# Altium schematic sheet COM.SchDoc(COM)
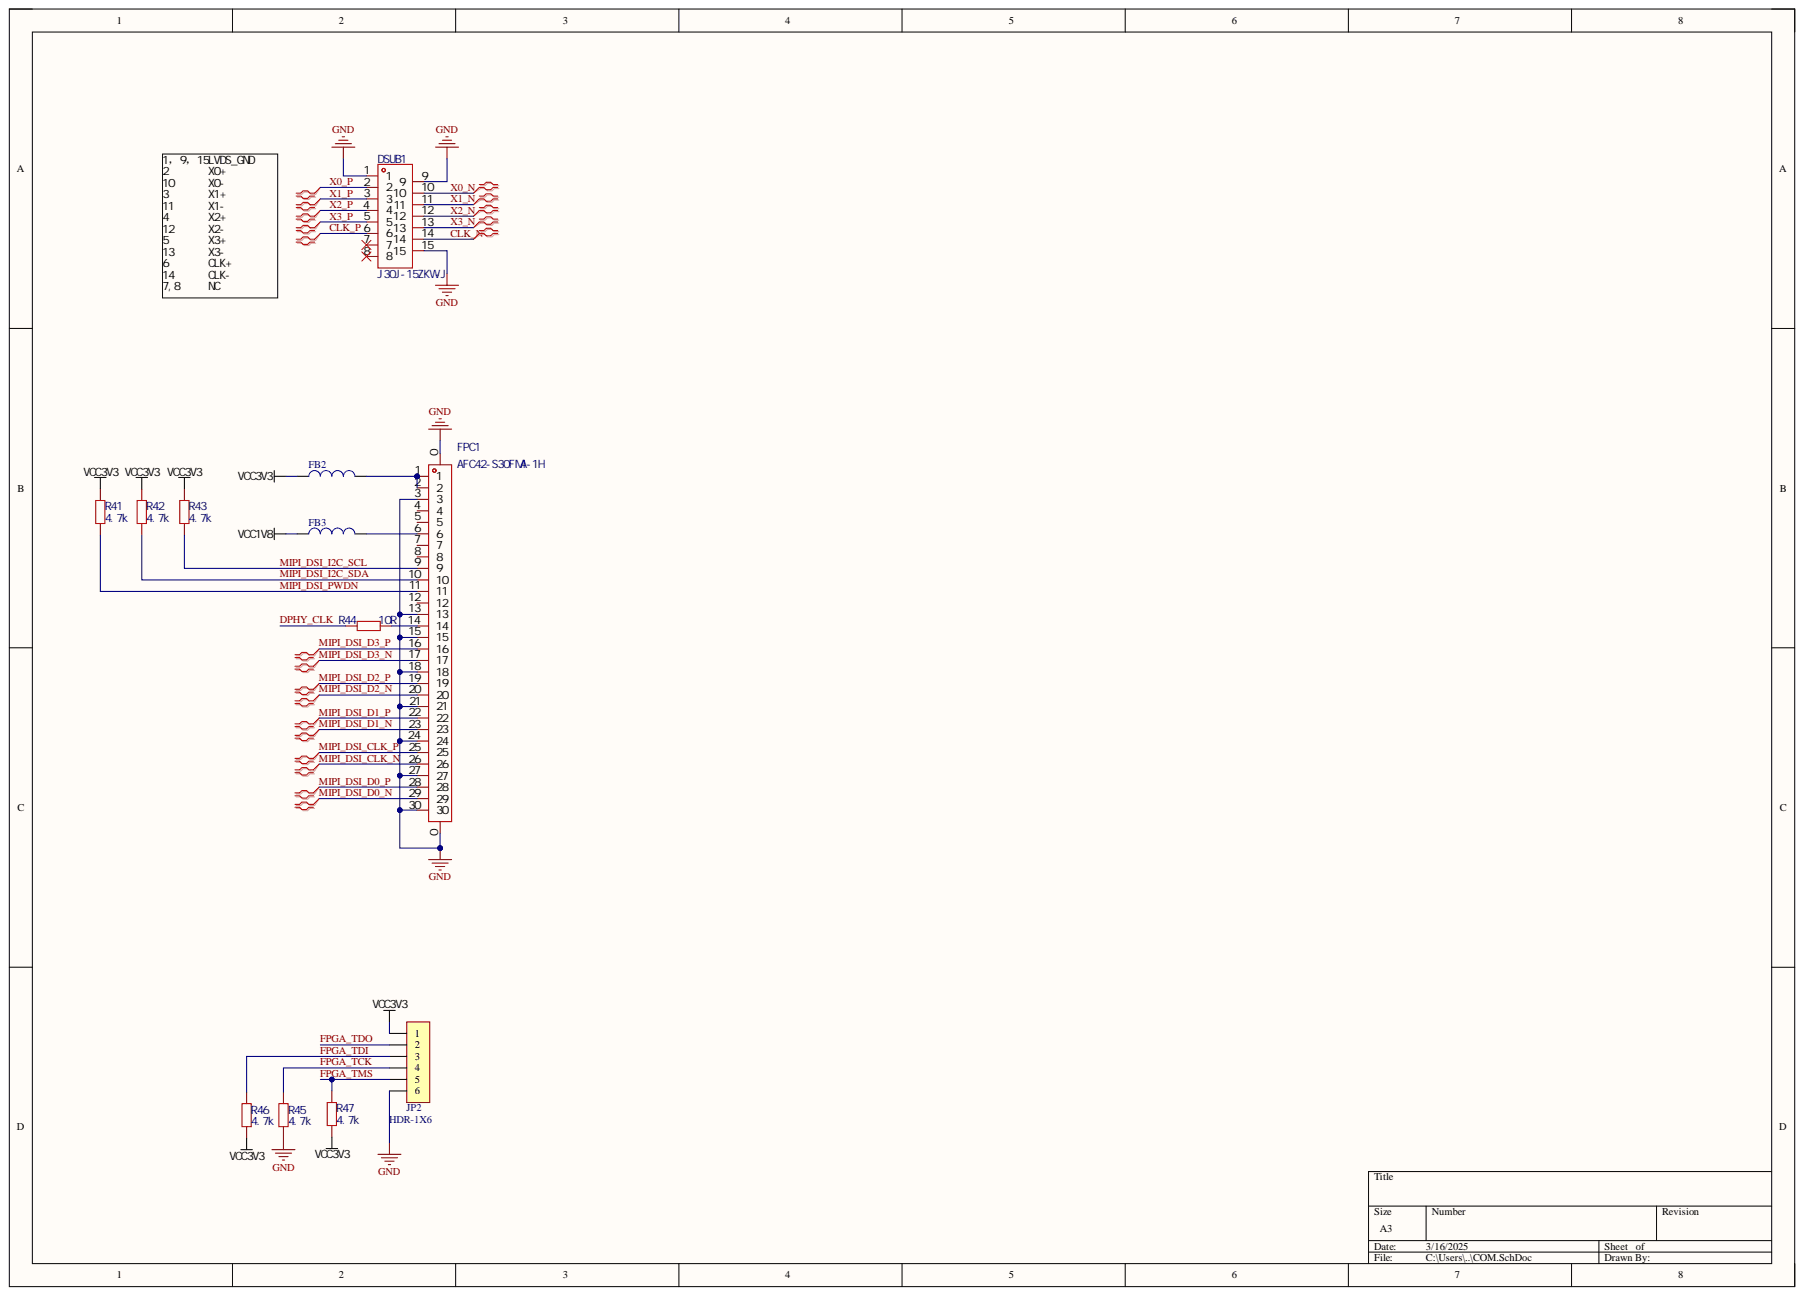
[diagram p0: COM.SchDoc]

## Parts
DSUB1  J30J-15ZKW-J  [DSUB-TH_J30J-15ZKW-J]
FB2  Inductor  [1608[0603]]  (value not drawn)
FB3  Inductor  [1608[0603]]  (value not drawn)
FPC1  AFC42-S30FMA-1H  [CONN-SMD_30P-P0.50_L3.1-W17.0]
JP2  HDR-1X6  [HDR1X6]
R41  4.7k  [0402]
R42  4.7k  [0402]
R43  4.7k  [0402]
R44  10R  [0402]
R45  4.7k  [0402]
R46  4.7k  [0402]
R47  4.7k  [0402]

## Nets
GND: DSUB1.1 DSUB1.9 DSUB1.15 FPC1.0 FPC1.3 FPC1.13 FPC1.15 FPC1.18 FPC1.21 FPC1.24 FPC1.27 FPC1.30 JP2.6 R45.2
VCC1V8: FB3.1
VCC3V3: FB2.1 JP2.1 R41.2 R42.2 R43.2 R46.2 R47.2
CLK_N: DSUB1.14
CLK_P: DSUB1.6
DPHY_CLK: R44.1
FPGA_TCK: JP2.4 R45.1
FPGA_TDI: JP2.3 R46.1
FPGA_TDO: JP2.2
FPGA_TMS: JP2.5 R47.1
MIPI_DSI_CLK_N: FPC1.26
MIPI_DSI_CLK_P: FPC1.25
MIPI_DSI_D0_N: FPC1.29
MIPI_DSI_D0_P: FPC1.28
MIPI_DSI_D1_N: FPC1.23
MIPI_DSI_D1_P: FPC1.22
MIPI_DSI_D2_N: FPC1.20
MIPI_DSI_D2_P: FPC1.19
MIPI_DSI_D3_N: FPC1.17
MIPI_DSI_D3_P: FPC1.16
MIPI_DSI_I2C_SCL: FPC1.9 R43.1
MIPI_DSI_I2C_SDA: FPC1.10 R42.1
MIPI_DSI_PWDN: FPC1.11 R41.1
X0_N: DSUB1.10
X0_P: DSUB1.2
X1_N: DSUB1.11
X1_P: DSUB1.3
X2_N: DSUB1.12
X2_P: DSUB1.4
X3_N: DSUB1.13
X3_P: DSUB1.5
NetFB2_2: FB2.2 FPC1.1 FPC1.2
NetFB3_2: FB3.2 FPC1.6
NetFPC1_14: FPC1.14 R44.2

Not connected: DSUB1 7 8; FPC1 4 5 7 8 12

## Library data of the parts
DSUB1 = J30J-15ZKW-J: Add into BOM: yes; Component Kind: Standard; Convert to PCB: yes; Device: J30J-15ZKW-J; JLCPCB Part Class: 扩展库; LCSC Part Name: J30J-15ZKW-J; Manufacturer Part: J30J-15ZKW-J; PCB3D: DSUB-TH_J30J-15ZKW-J; Supplier: LCSC; Supplier Footprint: THrough hole; Supplier Part: C9900039407; Symbol: J30J-15ZKW-J
FB2 FB3 = Inductor: Code_IEC: 1005; Code_JEITA: 0402; Component Kind: Standard; Description: Inductor; LatestRevisionDate: 29-May-2009; LatestRevisionNote: IPC-7351 Footprint Added.; Library Name: Miscellaneous Devices.IntLib; PackageDescription: Chip Inductor; PackageReference: 0402-A; Published: 8-Jun-2000; Signal Integrity: Inductor; Simulation: INDUCTOR; Publisher: Altium Limited
FPC1 = AFC42-S30FMA-1H: 锁定特性:翻盖式;触点类型:双侧触点/上下接;触点数量:30P;间距:0.5mm;安装类型:卧贴;; Manufacturer: JS(钜硕电子); Add into BOM: yes; FFC, FCB Thickness: 0.3mm; Locking Feature: 翻盖式; Number of Contacts: 30P; Pitch: 0.5mm; Component Kind: Standard; Contact Type: 双侧触点/上下接; Convert to PCB: yes; Datasheet: https://atta.szlcsc.com/upload/public/pdf/source/20191211/C466536_B97DC02FCD585445C3F43DDE167669A7.pdf; Device: AFC42-S30FMA-1H; Height Above Board: 1mm; JLCPCB Part Class: 扩展库; LCSC Part Name: 间距:0.5mm P数:30P 翻盖式 双侧触点/上下接; Manufacturer Part: AFC42-S30FMA-1H; Mounting Type: 卧贴; PCB3D: CONN-SMD_FPC0.50-WS-30P; Supplier: LCSC; Supplier Footprint: SMD,P=0.5mm,卧贴; Supplier Part: C466535; Symbol: AFC42-S30FMA-1H
JP2 = Header 6: 6P接插件; 封装库来自淘宝卖家ID：黄晶宝石: 其他店铺购入均为盗版封装库，请立即申请退款，本店现金奖励50~200元; 联系卖家，免费查找封装: 唯一出售店铺网址https://shop463601444.taobao.com; BOM名称: 6P接插件; Component Kind: Standard; Library Name: 5.5 - 2.54mm连接器(KF2EDGK_XH2.54_2.54排针排母).SchLib
R41-R47 = RC-02K4422FT: 电阻类型:厚膜电阻;阻值:44.2kΩ;精度:±1%;功率:62.5mW;最大工作电压:50V;温度系数:±100ppm/℃;; Manufacturer: FH(风华); Add into BOM: yes; Overload Voltage (Max): 50V; Power(Watts): 62.5mW; Symbol: RS-02K4422FT; Tolerance: ±1%; Value: 44.2kΩ; Component Kind: Standard; Convert to PCB: yes; Datasheet: https://atta.szlcsc.com/upload/public/pdf/source/20140616/1457707091974.pdf; Device: RC-02K4422FT; JLCPCB Part Class: 扩展库; LCSC Part Name: 厚膜电阻 44.2kΩ ±1% 62.5mW; Manufacturer Part: RC-02K4422FT; Operating Temperature Range: -55℃~+155℃; PCB3D: R0402_L1.0-W0.5-H0.5; Supplier: LCSC; Supplier Footprint: 0402; Supplier Part: C140153; Temperature Coefficient: ±100ppm/℃; Type: 厚膜电阻; Library Name: schdoc1.SCHLIB
